# Altium schematic sheet DEVICE.SchDoc(DEVICE)
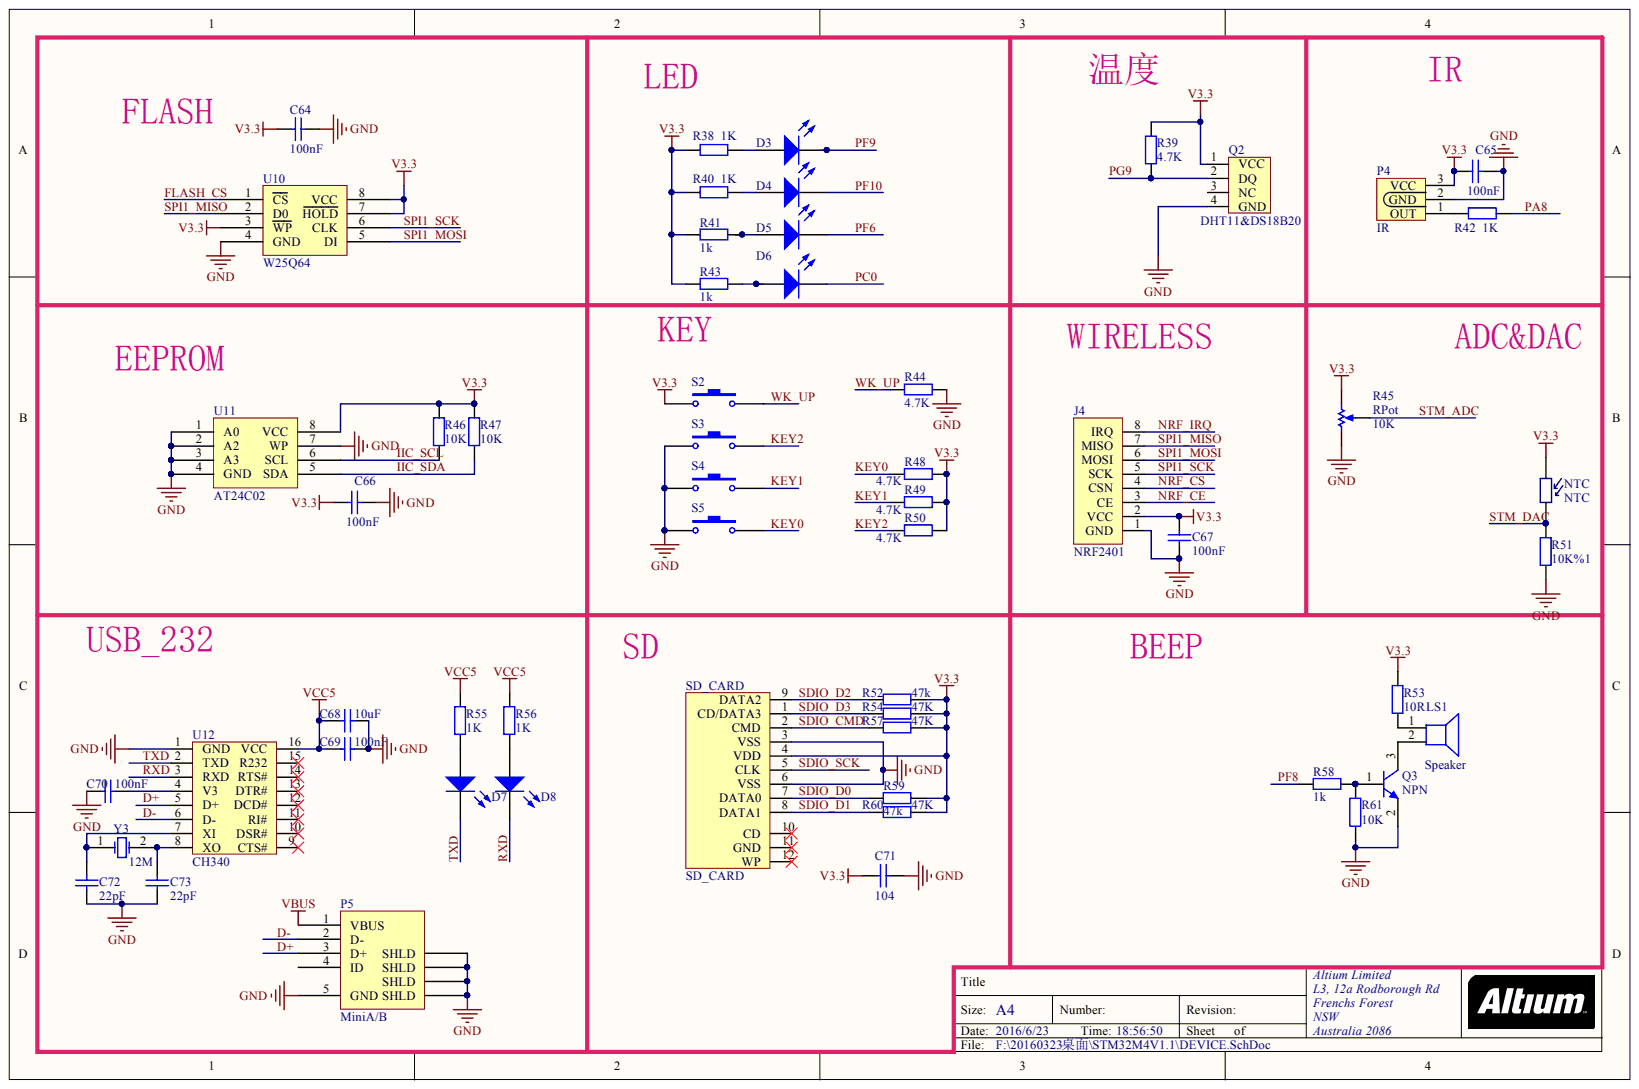
[diagram p0: DEVICE.SchDoc]

## Parts
C64  value 100nF  [0603]  (hidden comment: Cap)
C65  value 100nF  [0603]  (hidden comment: Cap)
C66  value 100nF  [0603]  (hidden comment: Cap)
C67  value 100nF  [0603]  (hidden comment: Cap)
C68  value 10uF  [0805]  (hidden comment: Cap)
C69  value 100nF  [0603]  (hidden comment: Cap)
C70  value 100nF  [0603]  (hidden comment: Cap)
C71  value 104  [0603]  (hidden comment: Cap)
C72  value 22pF  [0603]  (hidden comment: Cap)
C73  value 22pF  [0603]  (hidden comment: Cap)
D3  LED0  [LED]  (value not drawn)
D4  LED0  [LED]  (value not drawn)
D5  LED0  [LED]  (value not drawn)
D6  LED0  [LED]  (value not drawn)
D7  LED0  [LED]  (value not drawn)
D8  LED0  [LED]  (value not drawn)
J4  NRF2401  [WPZ_2x4]
LS1  Speaker  [Speaker]
NTC  NTC  [NTC]
P4  IR  [IR]
P5  MiniA/B  [MINI_USB]
Q2  DHT11&DS18B20  [DHT11]
Q3  NPN
R38  value 1K  [0603]  (hidden comment: Res2)
R39  value 4.7K  [0603]  (hidden comment: Res2)
R40  value 1K  [0603]  (hidden comment: Res2)
R41  value 1k  [0603]  (hidden comment: Res2)
R42  value 1K  [0603]  (hidden comment: Res2)
R43  value 1k  [0603]  (hidden comment: Res2)
R44  value 4.7K  [0603]  (hidden comment: Res2)
R45  RPot  value 10K  [KT_RES]
R46  value 10K  [0603]  (hidden comment: Res2)
R47  value 10K  [0603]  (hidden comment: Res2)
R48  value 4.7K  [0603]  (hidden comment: Res2)
R49  value 4.7K  [0603]  (hidden comment: Res2)
R50  value 4.7K  [0603]  (hidden comment: Res2)
R51  value 10K%1  [0603]  (hidden comment: Res2)
R52  value 47k  [0603]  (hidden comment: Res2)
R53  value 10R  [0603]  (hidden comment: Res2)
R54  value 47K  [0603]  (hidden comment: Res2)
R55  value 1K  [0603]  (hidden comment: Res2)
R56  value 1K  [0603]  (hidden comment: Res2)
R57  value 47K  [0603]  (hidden comment: Res2)
R58  value 1k  [0603]  (hidden comment: Res2)
R59  value 47k  [0603]  (hidden comment: Res2)
R60  value 47K  [0603]  (hidden comment: Res2)
R61  value 10K  [0603]  (hidden comment: Res2)
S2  SW-PB  [KEY]  (value not drawn)
S3  SW-PB  [KEY]  (value not drawn)
S4  SW-PB  [KEY]  (value not drawn)
S5  SW-PB  [KEY]  (value not drawn)
SD_CARD  SD_CARD  [SD_CAR]
U10  W25Q64  [SOP8]
U11  AT24C02  [SOIC8]
U12  CH340  [SOIC16]
Y3  value 12M  [XTAL]  (hidden comment: XTAL)

## Nets
GND: C64.1 C65.1 C66.1 C67.2 C68.2 C69.2 C70.1 C71.2 C72.2 C73.2 J4.1(GND) P4.2 P5.5(GND) P5.6(SHLD) P5.7(SHLD) P5.8(SHLD) P5.9(SHLD) Q2.4(GND) Q3.2 R44.2 R45.1 R51.1 R61.2 S3.1 S4.1 S5.1 SD_CARD.3(VSS) SD_CARD.6(VSS) U10.4(GND) U11.1(A0) U11.2(A2) U11.3(A3) U11.4(GND) U11.7(WP) U12.1(GND)
V3.3: C64.2 C65.2 C66.2 C67.1 C71.1 J4.2(VCC) NTC.1 P4.3 Q2.1(VCC) R38.2 R39.1 R40.2 R41.1 R43.1 R45.3 R46.2 R47.2 R48.2 R49.2 R50.2 R52.2 R53.1 R54.1 R57.1 R59.2 R60.1 S2.1 SD_CARD.4(VDD) U10.3(WP) U10.7(HOLD) U10.8(VCC) U11.8(VCC)
VBUS: P5.1(VBUS)
VCC5: C68.1 C69.1 R55.1 R56.1 U12.16(VCC)
D+: P5.3(D+) U12.5(D+)
D-: P5.2(D-) U12.6(D-)
FLASH_CS: U10.1(CS)
IIC_SCL: R46.1 U11.6(SCL)
IIC_SDA: R47.1 U11.5(SDA)
KEY0: R48.1 S5.2
KEY1: R49.1 S4.2
KEY2: R50.1 S3.2
NRF_CE: J4.3(CE)
NRF_CS: J4.4(CSN)
NRF_IRQ: J4.8(IRQ)
PA8: R42.1
PC0: D6.2
PF6: D5.2
PF8: R58.1
PF9: D3.2
PF10: D4.2
PG9: Q2.2(DQ) R39.2
RXD: D8.2 U12.3(RXD)
SDIO_CMD: R57.2 SD_CARD.2(CMD)
SDIO_D0: R59.1 SD_CARD.7(DATA0)
SDIO_D1: R60.2 SD_CARD.8(DATA1)
SDIO_D2: R52.1 SD_CARD.9(DATA2)
SDIO_D3: R54.2 SD_CARD.1(CD/DATA3)
SDIO_SCK: SD_CARD.5(CLK)
SPI1_MISO: J4.7(MISO) U10.2(D0)
SPI1_MOSI: J4.6(MOSI) U10.5(DI)
SPI1_SCK: J4.5(SCK) U10.6(CLK)
STM_ADC: R45.2
STM_DAC: NTC.2 R51.2
TXD: D7.2 U12.2(TXD)
WK_UP: R44.1 S2.2
NetC70_2: C70.2 U12.4(V3)
NetC72_1: C72.1 U12.7(XI) Y3.1
NetC73_1: C73.1 U12.8(XO) Y3.2
NetD3_1: D3.1 R38.1
NetD4_1: D4.1 R40.1
NetD5_1: D5.1 R41.2
NetD6_1: D6.1 R43.2
NetD7_1: D7.1 R55.2
NetD8_1: D8.1 R56.2
NetLS1_1: LS1.1 R53.2
NetLS1_2: LS1.2 Q3.3
NetP4_1: P4.1 R42.2
NetQ3_1: Q3.1 R58.2 R61.1

Not connected: P5 4(ID); Q2 3(NC); SD_CARD 10(CD) 11(GND) 12(WP); U12 9(CTS#) 10(DSR#) 11(RI#) 12(DCD#) 13(DTR#) 14(RTS#) 15(R232)

## Library data of the parts
C64-C73 = Cap: Capacitor; Component Kind: Standard; LatestRevisionDate: 17-Jul-2002; LatestRevisionNote: Re-released for DXP Platform.; Library Name: Miscellaneous Devices.IntLib; PackageDescription: Radial Cap, Thru-Hole; 2 Leads; 0.3 in Pin Spacing; PackageReference: RAD-0.3; Published: 8-Jun-2000; Signal Integrity: Cap; Simulation: CAP; Publisher: Altium Limited
D3-D8 = LED0: Typical INFRARED GaAs LED; Component Kind: Standard; LatestRevisionDate: 08-Jul-2005; LatestRevisionNote: Mech Layer 1 removed.; Library Name: Miscellaneous Devices.IntLib; PackageDescription: LED; 2 Leads; PackageReference: LED-0; Published: 8-Jun-2000; Publisher: Altium Limited
LS1 = Speaker: Loudspeaker; Component Kind: Standard; LatestRevisionDate: 17-Jul-2002; LatestRevisionNote: Re-released for DXP Platform.; Library Name: MyLibrary.SchLib; PackageReference: PIN2; Published: 24-Mar-1999; Publisher: Altium Limited
Q2 = DHT11: 数字温湿度传感器; Component Kind: Standard; Library Name: STM32开发板底板封装库.IntLib
R38-R44 R46-R61 = Res2: Resistor; Component Kind: Standard; LatestRevisionDate: 17-Jul-2002; LatestRevisionNote: Re-released for DXP Platform.; PackageDescription: Axial Device, Thru-Hole; 2 Leads; 0.4 in Pin Spacing; PackageReference: AXIAL-0.4; Published: 8-Jun-2000; Publisher: Altium Limited
  R38 R40 R42 R44 R46-R51 R53-R57 R60 R61: Library Name: Miscellaneous Devices.IntLib; Signal Integrity: Res; Simulation: RESISTOR
  R39: Library Name: Miscellaneous Devices.IntLib
  R41 R43 R52 R58 R59: Library Name: M4.SCHLIB; Signal Integrity: Res; Simulation: RESISTOR
R45 = RPot: Potentiometer; Set Position: 0.5; Sim Note: Enter the Resistance value and the set point into parameter fields.; Component Kind: Standard; LatestRevisionDate: 17-Jul-2002; LatestRevisionNote: Re-released for DXP Platform.; Library Name: MyLibrary.SchLib; PackageDescription: Variable Resistor, Thru-Hole; 3 Leads; PackageReference: VR5; Published: 8-Jun-2000; Publisher: Altium Limited
S2-S5 = SW-PB: Switch; Component Kind: Standard; LatestRevisionDate: 17-Jul-2002; LatestRevisionNote: Re-released for DXP Platform.; Library Name: Miscellaneous Devices.IntLib; PackageDescription: Switch; 2 Leads; PackageReference: SPST-2; Published: 24-Mar-1999; Publisher: Altium Limited
U10 = W25Q64: FLASH; Component Kind: Standard; Library Name: MyLibrary.IntLib
U11 = AT24C02: EEPROM; Component Kind: Standard; Library Name: MyLibrary.IntLib
U12 = CH340: USB2UART; Component Kind: Standard; Library Name: MyLibrary.IntLib
Y3 = XTAL: Crystal Oscillator; Component Kind: Standard; LatestRevisionDate: 17-Jul-2002; LatestRevisionNote: Re-released for DXP Platform.; Library Name: Miscellaneous Devices.IntLib; PackageDescription: Crystal, Thru-Hole; 2 Leads; Body 3.1 x 8.2 mm (Dia.xH); PackageReference: R38; Published: 8-Jun-2000; Simulation: XTAL; Publisher: Altium Limited
J4 = NRF2401: Component Kind: Standard; Library Name: MyLibrary.IntLib
NTC = NTC: Component Kind: Standard; Library Name: WARSHIP.SCHLIB
P4 = IR: Component Kind: Standard; Library Name: MyLibrary.SchLib
P5 = MiniA/B: Component Kind: Standard; Library Name: MyLibrary.IntLib
Q3 = NPN: Component Kind: Standard; Library Name: MyLibrary.SchLib
SD_CARD = SD_CARD: Component Kind: Standard; Library Name: MyLibrary.IntLib
